# Altium schematic sheet HMI.SchDoc
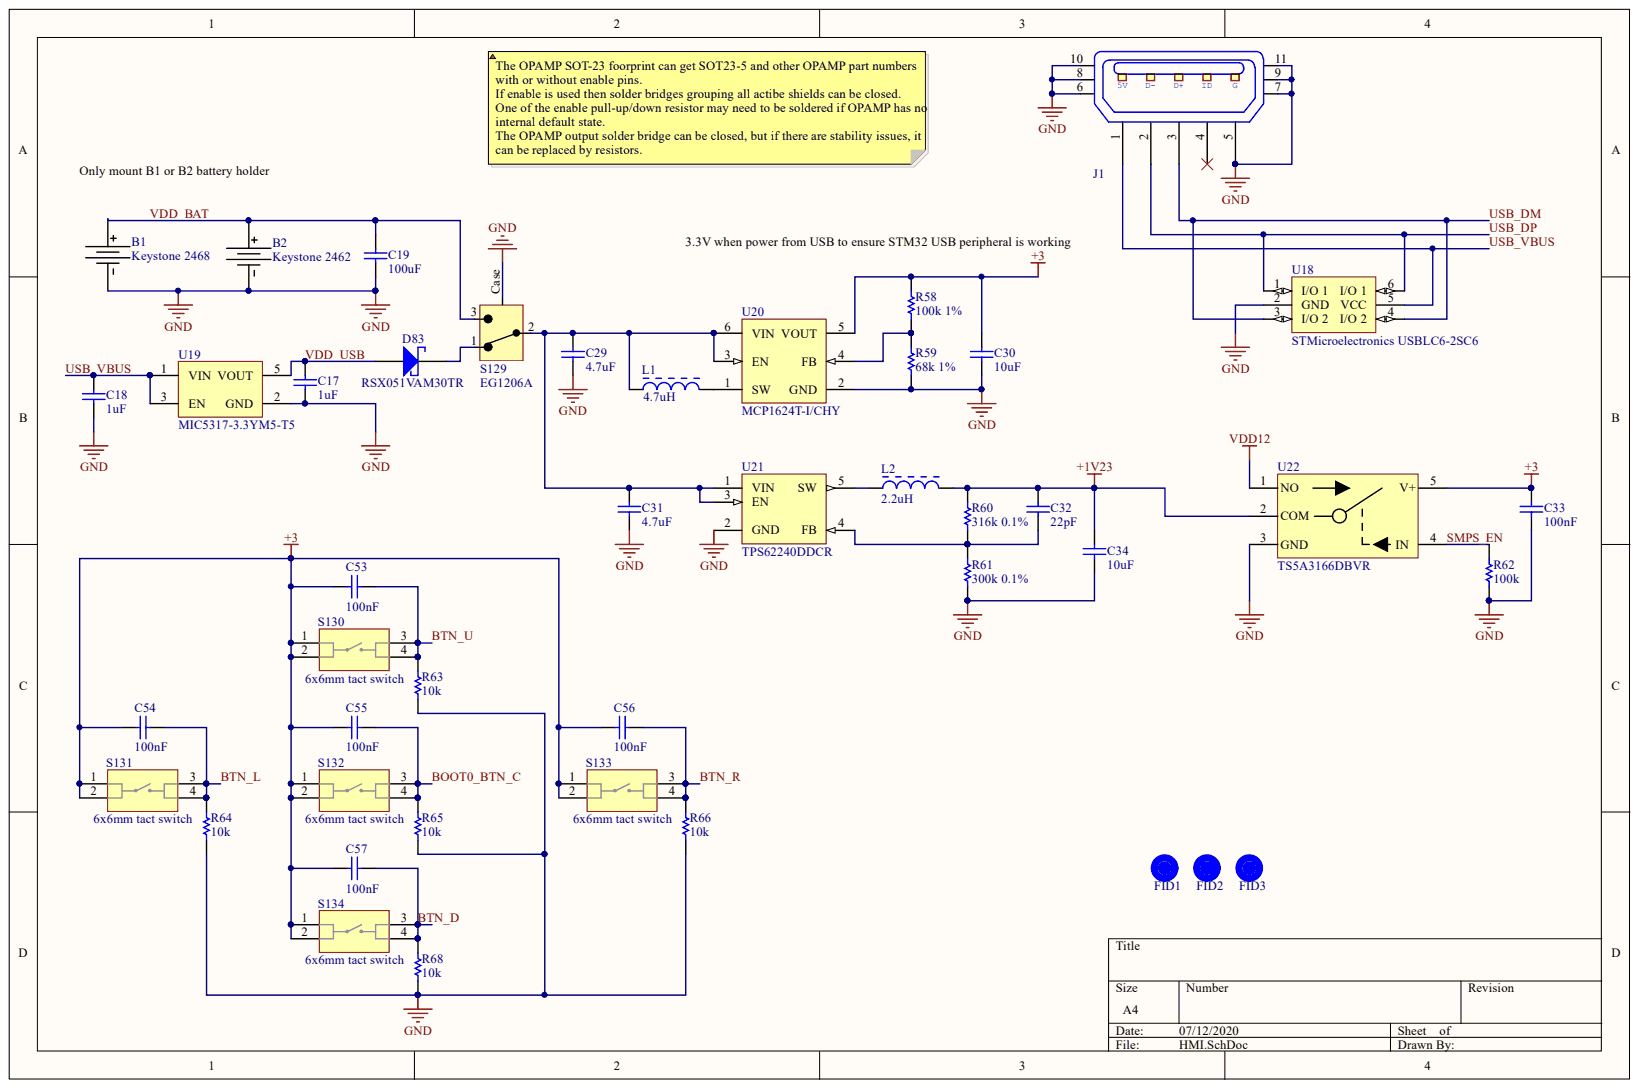
[diagram p0: HMI.SchDoc]

## Parts
B1  Keystone 2468  [PCB-372er05q764edivmfsgk-1]
B2  Keystone 2462  [PCB-4nz08h0mgqelqvr7t0vq-1]
C17  1uF  [CAPC1608X08N]
C18  1uF  [CAPC1608X08N]
C19  100uF  [CAPC3216X178X50NL20T25]
C29  4.7uF  [CAPC1608X08N]
C30  10uF  [CAPC1608X08N]
C31  4.7uF  [CAPC1608X08N]
C32  22pF  [PCB-srj5nzvxxiuyr0ufdy6u-1]
C33  100nF  [PCB-srj5nzvxxiuyr0ufdy6u-1]
C34  10uF  [CAPC1608X08N]
C53  100nF  [PCB-srj5nzvxxiuyr0ufdy6u-1]
C54  100nF  [PCB-srj5nzvxxiuyr0ufdy6u-1]
C55  100nF  [PCB-srj5nzvxxiuyr0ufdy6u-1]
C56  100nF  [PCB-srj5nzvxxiuyr0ufdy6u-1]
C57  100nF  [PCB-srj5nzvxxiuyr0ufdy6u-1]
D83  RSX051VAM30TR  [PCB-pdf5zse1jkbqdpg1nzvf-1]
FID1  Fiducial  [PCB-1-3mmFID]  (value not drawn)
FID2  Fiducial  [PCB-1-3mmFID]  (value not drawn)
FID3  Fiducial  [PCB-1-3mmFID]  (value not drawn)
J1  Amphenol ICC / FCI 10118193-0001LF  [10118193]  (value not drawn)
L1  4.7uH  [PCB-1lsrypisir8xps70co3s-1]
L2  2.2uH  [PCB-3556u06u6vjx5cjzobar-1]
R58  100k 1%  [RESC1005X04M]
R59  68k 1%  Tolerance: 1%  [RESC1005X04M]
R60  316k 0.1%  [RESC1005X04M]
R61  300k 0.1%  [RESC1005X04M]
R62  100k  [RESC1005X04M]
R63  10k  [RESC1005X04M]
R64  10k  [RESC1005X04M]
R65  10k  [RESC1005X04M]
R66  10k  [RESC1005X04M]
R68  10k  [RESC1005X04M]
S129  EG1206A  [PCB-i2avmqml980kjsbvhtwo-1]
S130  6x6mm tact switch  [PCB-01q4xvz8qs5ux8qkrnb3-1]
S131  6x6mm tact switch  [PCB-01q4xvz8qs5ux8qkrnb3-1]
S132  6x6mm tact switch  [PCB-01q4xvz8qs5ux8qkrnb3-1]
S133  6x6mm tact switch  [PCB-01q4xvz8qs5ux8qkrnb3-1]
S134  6x6mm tact switch  [PCB-01q4xvz8qs5ux8qkrnb3-1]
U18  STMicroelectronics USBLC6-2SC6  Manufacturer Part Number: USBLC6-2SC6  [SOT95P280X145-6N]
U19  MIC5317-3.3YM5-T5  [PCB-95p4ttkl4aw68la3u91n-1]
U20  MCP1624T-I/CHY  [MCHP-SOT-23-CHY6_N]
U21  TPS62240DDCR  [SOT95P280X110-5N]
U22  TS5A3166DBVR  [PCB-b6b1b3q63w4r88dyx4jq-1]

## Nets
+1V23: C32.2 C34.2 L2.2 R60.1 U22.2(COM)
+3: C30.2 C33.2 C53.2 C54.2 C55.2 C56.2 C57.2 R58.1 S130.1 S130.2 S131.1 S131.2 S132.1 S132.2 S133.1 S133.2 S134.1 S134.2 U20.5(VOUT) U22.5(V+)
GND: B1.2 B2.2 C17.1 C18.1 C19.1 C29.1 C30.1 C31.1 C33.1 C34.1 J1.5 J1.6 J1.7 J1.8 J1.9 J1.10 J1.11 R59.2 R61.2 R62.2 R63.2 R64.2 R65.2 R66.2 R68.2 S129.Case U18.2(GND) U19.2(GND) U20.2(GND) U21.2(GND) U22.3(GND)
VDD12: U22.1(NO)
BOOT0_BTN_C: C55.1 R65.1 S132.3 S132.4
BTN_D: C57.1 R68.1 S134.3 S134.4
BTN_L: C54.1 R64.1 S131.3 S131.4
BTN_R: C56.1 R66.1 S133.3 S133.4
BTN_U: C53.1 R63.1 S130.3 S130.4
SMPS_EN: R62.1 U22.4(IN)
USB_DM: J1.3 U18.3(I/O_2) U18.4(I/O_2)
USB_DP: J1.2 U18.1(I/O_1) U18.6(I/O_1)
USB_VBUS: C18.2 J1.1 U18.5(VCC) U19.1(VIN) U19.3(EN)
VDD_BAT: B1.1 B2.1 C19.2 S129.3
VDD_USB: C17.2 D83.A U19.5(VOUT)
NetC29_2: C29.2 C31.2 L1.1 S129.2 U20.3(EN) U20.6(VIN) U21.1(VIN) U21.3(EN)
NetC32_1: C32.1 R60.2 R61.1 U21.4(FB)
NetD83_C: D83.C S129.1
NetL1_2: L1.2 U20.1(SW)
NetL2_1: L2.1 U21.5(SW)
NetR58_2: R58.2 R59.1 U20.4(FB)

Not connected: J1 4

## Library data of the parts
B1 = CMP-3614-16: Cylindrical Battery Contacts, Clips, Holders & Springs 2AAA PC MNT HOLDER; Manufacturer Part Number: 2468; Lead-Free Status: Lead Free; Wire Gauge: 26.0 AWG; Component Kind: Standard; Contact Plating: Nickel; Library Name: FavoritesLibrary; Material: Plastic; Mounting Style: Through Hole, PC Board, PCB; OctopartID: 102dd927ce1acfea; Octopart URL: https://octopart.com/2468-keystone-64337; Packaging: Bag; REACH SVHC Compliance: No SVHC; RoHS: Compliant
B2 = CMP-10f5d9ff7ce4cf32-10: ZING 2462 Parking Sign, 18 x 12In, GRN/WHT, Eco Car. More details: Parking Sign, High Efficiency Vehicle Parking Only...; Manufacturer Part Number: 2462; Lead-Free Status: Lead Free; Component Kind: Standard; Contact Plating: Nickel; Library Name: FavoritesLibrary; Material: Plastic; Mounting Style: Through Hole, PCB; OctopartID: 10f5d9ff7ce4cf32; Octopart URL: http://octopart.com/2462-keystone-18807; Packaging: Bag; REACH SVHC Compliance: No SVHC; RoHS: Compliant
C17 C18 = CMP-nocyn7nhex2srcneo663-1: 0603 1uF 10V X5R; Body Height: 0.8mm; Body Length: 1.6mm; Capacitance: 100nF; Package (Imperial): 0603; Package (Metric): 1608; Tolerance: 10%; Body Width: 0.8mm; Component Kind: Standard; CustomComponentID: nocyn7nhex2srcneo663; Library Name: CommunityVault
C19 = CMP-3555311-3: 1206 100uF 6.3V X5R; Manufacturer Part Number: TBD; Capacitance: 1uF; Capacitance Tolerance: ±10%; Lead-Free Status: Lead Free; Voltage Rating (DC): 25.0 V; Case/Package: 1206; Component Kind: Standard; Dielectric Characteristic: X5R; Library Name: CommunityVault; OctopartID: 2b51e29b18fff496; Octopart Url: TBD; RoHS: Compliant
C29 C31 = CMP-nocyn7nhex2srcneo663-1: 0603 4.7uF 6.3V X5R; Body Height: 0.8mm; Body Length: 1.6mm; Capacitance: 100nF; Package (Imperial): 0603; Package (Metric): 1608; Tolerance: 10%; Body Width: 0.8mm; Component Kind: Standard; CustomComponentID: nocyn7nhex2srcneo663; Library Name: CommunityVault
C30 C34 = CMP-nocyn7nhex2srcneo663-1: 0603 10uF 6.3V X5R; Body Height: 0.8mm; Body Length: 1.6mm; Capacitance: 100nF; Package (Imperial): 0603; Package (Metric): 1608; Tolerance: 10%; Body Width: 0.8mm; Component Kind: Standard; CustomComponentID: nocyn7nhex2srcneo663; Library Name: CommunityVault
C32 = CMP-16527024-7: 0402 22pF 6.3V C0G; Capacitance: 100 nF; Capacitance Tolerance: ±10%; Dielectric Characteristic: X5R; Lead-Free Status: Lead Free; Voltage Rating (DC): 16.0 V; Case/Package: 0402; Component Kind: Standard; Library Name: CommunityVault; Mounting Style: Surface Mount; Number of Pins: 2; OctopartID: 6877a17fb5d5b7b0; Operating Temperature: -55.0 °C to 85.0 °C; Packaging: Cut Tape (CT), Reel, Tape & Reel (TR); REACH SVHC Compliance: No SVHC; RoHS: Compliant; Size-Height: 560 µm; Size-Length: 1.00 mm; Size-Width: 500 µm
C33 C53-C57 = CMP-16527024-7: 0402 100nF 6.3V X5R; Capacitance Tolerance: ±10%; Lead-Free Status: Lead Free; Voltage Rating (DC): 16.0 V; Capacitance: 100 nF; Case/Package: 0402; Component Kind: Standard; Dielectric Characteristic: X5R; Library Name: CommunityVault; Mounting Style: Surface Mount; Number of Pins: 2; OctopartID: 6877a17fb5d5b7b0; Operating Temperature: -55.0 °C to 85.0 °C; Packaging: Cut Tape (CT), Reel, Tape & Reel (TR); REACH SVHC Compliance: No SVHC; RoHS: Compliant; Size-Height: 560 µm; Size-Length: 1.00 mm; Size-Width: 500 µm
D83 = CMP-5d68976b40d282af-2: Schottky diode, Vf<0.25V@10mA/25°C Vrrm>25V SOD-323HE; Lead-Free Status: Lead Free; Component Kind: Standard; Library Name: CommunityVault; Operating Temperature: 150 °C (max)
FID1-FID3 = CMP-k7irs8ss2hxyrp0kofj8-1: fiducial mark for assembly; Component Kind: Standard; CustomComponentID: k7irs8ss2hxyrp0kofj8; Library Name: FavoritesLibrary
J1 = CMP-b7d77f99eeaa6810-27: FCI Right Angle Surface Mount Mount Female USB Connector; 100 V ac; 1.8A; Lead-Free Status: Lead Free; Color: Black; Component Kind: Standard; Contact Material: Copper Alloy; Contact Plating: Gold; Gender: Female; Library Name: CommunityVault; Manufacturer Part Number: 10118193-0001LF; Mounting Style: Surface Mount; OctopartID: 3c80f0e8467fe347; Octopart URL: https://octopart.com/10118193-0001lf-amphenol+icc+%2F+fci-90333081; Orientation: Right Angle; Packaging: Reel; RoHS: Compliant
L1 = CMP-5f1694dba57280d1-1: Bourns SRN4026-4R7M 4.7uH DCR<0.1R Isat>0.8A 4x4mm; Inductance: 4.70 µH; Component Kind: Standard; Library Name: CommunityVault; Manufacturer Part Number: SRN4026-4R7M; Mounting Style: Surface Mount; OctopartID: 5f1694dba57280d1; Octopart URL: https://octopart.com/srn4026-4r7m-bourns-46653186; Packaging: Cut Tape (CT), Tape & Reel (TR); REACH SVHC Compliance: No SVHC; RoHS: Compliant
L2 = CMP-39bff13143bee678-2: Bourns SRN4018-2R2M 2.2uH DCR<0.1R Isat>0.4A 4x4mm; Inductance: 2.20 H; Component Kind: Standard; Library Name: CommunityVault; Manufacturer Part Number: SRN4018-2R2M; Number of Pins: 2; OctopartID: 39bff13143bee678; Octopart URL: https://octopart.com/srn4018-2r2m-bourns-25452391; Packaging: Cut Tape (CT); REACH SVHC Compliance: No SVHC; RoHS: Compliant
R58 = CMP-v99hy84itq0asdedzg70-1: 0402 100k 1%; Body Height: 0.35mm; Body Length: 1mm; Body Width: 0.5mm; Package (Imperial): 0402; Package (Metric): 01005; Resistance: 10K?; Component Kind: Standard; CustomComponentID: v99hy84itq0asdedzg70; Library Name: CommunityVault; Tolerance: 1%
R59 = CMP-v99hy84itq0asdedzg70-1: 0402 68k 1%; Body Height: 0.35mm; Body Length: 1mm; Body Width: 0.5mm; Package (Imperial): 0402; Package (Metric): 01005; Resistance: 10K?; Component Kind: Standard; CustomComponentID: v99hy84itq0asdedzg70; Library Name: CommunityVault
R60 = CMP-v99hy84itq0asdedzg70-1: 0402 330k 0.1%; Body Height: 0.35mm; Body Length: 1mm; Body Width: 0.5mm; Package (Imperial): 0402; Package (Metric): 01005; Resistance: 10K?; Tolerance: 1%; Component Kind: Standard; CustomComponentID: v99hy84itq0asdedzg70; Library Name: CommunityVault
R61 = CMP-v99hy84itq0asdedzg70-1: 0402 300k 0.1%; Body Height: 0.35mm; Body Length: 1mm; Body Width: 0.5mm; Package (Imperial): 0402; Package (Metric): 01005; Resistance: 10K?; Tolerance: 1%; Component Kind: Standard; CustomComponentID: v99hy84itq0asdedzg70; Library Name: CommunityVault
R62 = CMP-v99hy84itq0asdedzg70-1: 0402 100k 5%; Body Height: 0.35mm; Body Length: 1mm; Body Width: 0.5mm; Package (Imperial): 0402; Package (Metric): 01005; Resistance: 10K?; Tolerance: 1%; Component Kind: Standard; CustomComponentID: v99hy84itq0asdedzg70; Library Name: CommunityVault
R63-R66 R68 = CMP-v99hy84itq0asdedzg70-1: 0402 10k 5%; Body Height: 0.35mm; Body Length: 1mm; Body Width: 0.5mm; Package (Imperial): 0402; Package (Metric): 01005; Resistance: 10K?; Tolerance: 1%; Component Kind: Standard; CustomComponentID: v99hy84itq0asdedzg70; Library Name: CommunityVault
S129 = CMP-7f7bb6614403a0bc-5: 3mm spacing; Actuator Type: Standard; Contacts Type: SPDT; Current Rating: 200 mA; Lead-Free Status: Lead Free; Number of Positions: 2; Component Kind: Standard; Contact Material: Copper Alloy; Contact Plating: Silver; Library Name: CommunityVault; Manufacturer Part Number: EG1206A; Mounting Style: PCB, Through Hole; OctopartID: 7f7bb6614403a0bc; Octopart URL: http://octopart.com/eg1206a-e-switch-1056286; Orientation: Right Angle; Packaging: Bulk; RoHS: Compliant; Size-Height: 5.60 mm; Size-Length: 6.10 mm; Size-Width: 6.00 mm
S130-S134 = CMP-f7d33c1c71cf2814-4: Switch Push Button OFF (ON) SPST Round Button 0.05A 24VDC Momentary Contact PC Pins Thru-Hole; Component Kind: Standard; Library Name: CommunityVault
U18 = CMP-756ebe39126caaa9-8: USBLC6 Series 2 Line 6 V Uni / Bi-Directional ESD Protection - SOT-23-6; Data Rate: 480 Mbps; Lead-Free Status: Lead Free; Case/Package: SOT-23; Component Kind: Standard; Library Name: CommunityVault; Lifecycle Status: Active; OctopartID: 756ebe39126caaa9; Packaging: Cut Tape (CT), Tape & Reel (TR); REACH SVHC Compliance: No SVHC; RoHS: Compliant
U19 = CMP-82be484ff3a75d79-1: Single, High Performance 150mA LDO, 5 SOT-23 T/R; Component Kind: Standard; Library Name: CommunityVault; Lifecycle Status: Not Listed by Manufacturer; Manufacturer Part Number: MIC5317-3.3YM5-T5; Number of Pins: 5; OctopartID: 82be484ff3a75d79; Octopart URL: http://octopart.com/mic5317-3.3ym5-t5-microchip-66802815; Output Current: 150 mA; Packaging: Cut Tape (CT); REACH SVHC Compliance: No SVHC; RoHS: Compliant
U20 = CMP-1517822-2: Low-Voltage Input Boost Regulator for PIC® Microcontrollers, 175 mA, Input Voltage 0.65 to 5.5 V, Output Voltage 2.0 to 5.5 V, -40 to 85 degC, 6-pin SOT-23 (CHY6), Tape and Reel; Lead-Free Status: Lead Free; Number of Outputs: 1; Switching Frequency: 500000.0 Hz; Component Kind: Standard; Library Name: CommunityVault; Lifecycle Status: Active; Mounting Style: Surface Mount; Number of Pins: 6; OctopartID: 594a915a1cea868d; Octopart Url: https://octopart.com/mcp1624t-i%2Fchy-microchip-18505926; Packaging: Cut Tape (CT); REACH SVHC Compliance: No SVHC; RoHS: Compliant
U21 = CMP-e91020768cff36d7-2: 2.25MHz 300mA Step-Down Converter in 2x2mm SON/TSOT23 Package 5-SOT-23-THIN -40 to 85; Lead-Free Status: Lead Free; Output Voltage: 6.00 V; Quiescent Current: 15.0 µA; Case/Package: TSOT-23-5, SOT-23-5; Component Kind: Standard; Library Name: CommunityVault; Lifecycle Status: Active; Mounting Style: Surface Mount; Number of Pins: 5; Octopart URL: https://octopart.com/tps62240ddcr-texas+instruments-7094409; Operating Temperature: -40.0 °C to 85.0 °C; Output Current: 300 mA; Packaging: Reel; Supply Current: 15.0 µA
U22 = CMP-e1dd28d015f13e1a-4: Analog switch Ron<2R@3V; Bandwidth: 200 MHz; Contacts Type: SPST; Lead-Free Status: Lead Free; Number of Bits: 1; Supply Voltage (DC): 1.65 V to 5.50 V; Voltage Nodes: 1.80 V, 2.50 V, 3.00 V, 3.30 V, 5.00 V; Case/Package: SOT-23; Component Kind: Standard; Library Name: CommunityVault; Lifecycle Status: Active; Manufacturer Part Number: TS5A3166DBVR; Mounting Style: Surface Mount; Number of Channels: 1; Number of Pins: 5; OctopartID: e1dd28d015f13e1a; Octopart URL: http://octopart.com/ts5a3166dbvr-texas+instruments-512330; Packaging: Tape & Reel (TR), Cut Tape (CT); REACH SVHC Compliance: No SVHC; RoHS: Compliant
